# Altium schematic sheet main_scheme.SchDoc(main_scheme) (continued)

## Library data of the parts
C1 C2 = CAP 0402 - 27pF: Cap Ceramic 0402, 27pF, 25V,10%; PartNumber: C0402C270K3GACTU; Component Kind: Standard; ComponentLink1Description: myLinks; ComponentLink1URL: https://eu.mouser.com/ProductDetail/KEMET/C0402C270K3GACTU?qs=v7s4VpRpa0t5WS52qYyghQ%3D%3D; Library Name: Schlib1.SchLib; Package: 0402; Supplier 1: Mouser; Supplier Part Number 1: 80-C0402C270K3G; Value: 27pF
C3 C42 = CAP-0603-4.7uF: General Purpose Ceramic Capacitor, 0603,4.7uF,35V,10%; PartNumber: C1608X5R1V475K080AC; Component Kind: Standard; ComponentLink1Description: myLinks; ComponentLink1URL: https://cz.mouser.com/ProductDetail/TDK/C1608X5R1V475K080AC?qs=dfay7wIA1uF9DVjUL4K4oA%3D%3D; Library Name: Schlib1.SchLib; Package: 0603; Supplier 1: Mouser; Supplier Part Number 1: 810-C1608X5R1V475K; Value: 4.7uF
C4-C15 C18-C20 C40 C41 C43 = CAP 0402 - 100nF: Cap Ceramic 0402,0.1uF, 25V,10%; PartNumber: C0402C104K3RACTU; Component Kind: Standard; ComponentLink1Description: myLinks; ComponentLink1URL: https://eu.mouser.com/ProductDetail/KEMET/C0402C104K3RACTU?qs=YCcZ0GhAU2q7BaxZ%2FluQHA%3D%3D; Library Name: Schlib1.SchLib; Package: 0402; Supplier 1: Mouser; Supplier Part Number 1: 80-C0402C104K3R; Value: 100nF
C16 C17 = CAP-0603-2.2uF: General Purpose Ceramic Capacitor, 0603,2.2uF,35V,10%; PartNumber: C1608JB1V225K080AC; Component Kind: Standard; ComponentLink1Description: myLinks; ComponentLink1URL: https://cz.mouser.com/ProductDetail/TDK/C1608JB1V225K080AC?qs=xLDY6iXSiQbY%2FrhN9AA4AA%3D%3D; Library Name: Schlib1.SchLib; Package: 0603; Supplier 1: Mouser; Supplier Part Number 1: 810-C1608JB1V225K08C; Value: 2.2uF
D4 D10 = DIODE -FW:3A - 380mV, Rev: 40V: Schottky DIODE -  DO-214AC-2 -FW:3A - 380mV, Rev: 40V; PartNumber: SSA34HE3_A/I; Component Kind: Standard; ComponentLink1Description: New link; ComponentLink1URL: https://cz.mouser.com/ProductDetail/Vishay-General-Semiconductor/SSA34HE3_A-I?qs=asPD7ZL2j3VimMFbwwU%2FoA%3D%3D; Library Name: Schlib1.SchLib; Package: DO-214AC-2; Supplier 1: Mouser; Supplier Part Number 1: 78-SSA34HE3_A/I; Value: FW:3A - 380mV, Rev: 40V
D8 D13 = LED - 0603 - RED - 2V2: LED RED DIFFUSED 0603 SMD; PartNumber: SML-D12U1WT86; Value: RED LED - 2.2V; Component Kind: Standard; ComponentLink1Description: myLinks; ComponentLink1URL: https://cz.mouser.com/ProductDetail/ROHM-Semiconductor/SML-D12U1WT86?qs=M3jcYzEJUdEySuGkbjHEtQ%3D%3D; Library Name: Schlib1.SchLib; Package: 0602; Supplier 1: Mouser; Supplier Part Number 1: 755-SML-D12U1WT86
D14 = Diode - SK53AFL-TP: Diode - 5A shottky Rectifier, Fw: 5A, 0.7V Revers: 30V, DO-221AC-2; Component Kind: Standard; ComponentLink1Description: myLinks; ComponentLink1URL: https://cz.mouser.com/ProductDetail/Micro-Commercial-Components-MCC/SK53AFL-TP?qs=sGAEpiMZZMtbRapU8LlZD%252B6h%2FWulpAkrF6E5wLTt2R0xTCwpYWNMog%3D%3D; Library Name: Schlib1.SchLib; Package: DO-221AC-2; Supplier 1: Mouser; Supplier Part Number 1: 833-SK53AFL-TP; Value: Fw: 5A, 0.7V Revers: 30V
J1 = connector - 25x2 - 2x2mm - right angle: Connector - connector - 25x2 - 2x2mm, SAMTEC - right angle; PartNumber: STMM-125-02-S-D-RA; Component Kind: Standard; ComponentLink1Description: myLinks; ComponentLink1URL: https://cz.mouser.com/ProductDetail/Samtec/STMM-125-02-S-D-RA?qs=rU5fayqh%252BE3bRISkmlmqKg%3D%3D; Library Name: Schlib1.SchLib; Package: 25x2 - 2x2mm -right angle; Supplier 1: Mouser; Supplier Part Number 1: 200-STMM12502SDRA; Value: 25x2 - 2x2mm -right angle
J2 J16 = Connector - 2x5 -1.27 x 1.27mm: Connector - 2x5positions -  1.27mm x1.27mm; PartNumber: FTSH-105-04-F-D; Value: 2x5 1.27mm x 1.27mm; Component Kind: Standard; ComponentLink1Description: myLinks; ComponentLink1URL: https://cz.mouser.com/ProductDetail/Samtec/FTSH-105-04-F-D?qs=0lQeLiL1qyZx0H067ICkhw%3D%3D; Library Name: Schlib1.SchLib; Package: 2x5positions -  1.27mm x1.27mm; Supplier 1: Mouser; Supplier Part Number 1: 200-FTSH10504FDV
J4 J5 J10 J12-J14 J17-J22 = WAGO - single - 236-401: Wago connector - PCB to wire - single - 24A 320V; Component Kind: Standard; ComponentLink1Description: myLinks; ComponentLink1URL: https://cz.farnell.com/wago/236-401/terminal-block-wire-to-brd-1pos/dp/1891620?gclid=CjwKCAiAu5agBhBzEiwAdiR5tFj9uwuLgrlShO914YuSUEtsU0o4Hiox7ZjRnpSnFBbtyRYLbKYJWxoC0xgQAvD_BwE&mckv=sEoohdYPd_dc|pcrid|263733373421|kword|+wago%20+236-401|match|b|plid||slid||product||pgrid|56870816529|ptaid|kwd-298399736559|&CMP=KNC-GCZ-GEN-SKU-MDC; Library Name: Schlib1.SchLib; PartNumber: 236-401; Supplier 1: Farnell; Supplier Part Number 1: 1891620; Value: 24A 320V
P1 P2 P8 P9 P11 = Header - 3x1 2.54mm: Headers & Wire Housings 3P HEADER GOLD 15u single row; PartNumber: 5-146280-3; Component Kind: Standard; ComponentLink1Description: myLinks; ComponentLink1URL: https://cz.mouser.com/ProductDetail/TE-Connectivity/5-146280-3?qs=bwpFY26eRVf7xnwkMZzVxA%3D%3D; Library Name: Schlib1.SchLib; Package: 3x1 2.54mm; Supplier 1: Mouser; Supplier Part Number 1: 571-5-146280-3; Value: 3x1 2.54mm
P3 P10 P12 P13 = Header - 2x1 2.54mm: Male Header, Pitch 2.54 mm, 1 x 2 Position, Height 8.21 mm, Tail Length 3.05 mm, -65 to 105 degC, RoHS, Bulk; PartNumber: 5-146280-2; Component Kind: Standard; ComponentLink1Description: myLinks; ComponentLink1URL: https://cz.mouser.com/ProductDetail/TE-Connectivity/5-146280-2?qs=bwpFY26eRVcxHP%252BQexhLNQ%3D%3D; Library Name: Schlib1.SchLib; Package: 2x1 2.54mm; Supplier 1: Mouser; Supplier Part Number 1: 571-5-146280-2; Value: 2x1 2.54mm
R1-R4 R33 R51 = Resistor - 0402 -10k: Resistor - 0402 -  10k - 5% - 125mW; PartNumber: RC0402JR-7W10KL; Component Kind: Standard; ComponentLink1Description: myLinks; ComponentLink1URL: https://cz.mouser.com/ProductDetail/YAGEO/RC0402JR-7W10KL?qs=tggtontpCXPnY%2FhgQXv%2FLg%3D%3D; Library Name: Schlib1.SchLib; Package: 0402; Supplier 1: Mouser; Supplier Part Number 1: 603-RC0402JR-7W10KL; Value: 10k
R10 = Resistor - 0402 -120R: Resistor - 0402 -  120R - 1% - 100mW; PartNumber: ERJ-S02F1200X; Component Kind: Standard; ComponentLink1Description: myLinks; ComponentLink1URL: https://cz.mouser.com/ProductDetail/Panasonic/ERJ-S02F1200X?qs=Zyl8A9hlmJqrTWz%252BBOpJnw%3D%3D; Library Name: Schlib1.SchLib; Package: 0402; Supplier 1: Mouser; Supplier Part Number 1: 667-ERJ-S02F1200X; Value: 120R
R36 R42 = Resistor - 0402 - 4.87k: Resistor - 0402 -  4.87k - 1% - 100mW; PartNumber: ERJ-2RKF4871X; Component Kind: Standard; ComponentLink1Description: myLinks; ComponentLink1URL: https://cz.mouser.com/ProductDetail/Panasonic/ERJ-2RKF4871X?qs=YMBIwoNQYdV9ZzOLxQ6NUw%3D%3D; Library Name: Schlib1.SchLib; Package: 0402; Supplier 1: Mouser; Supplier Part Number 1: 667-ERJ-2RKF4871X; Value: 4.87k
R41 = RESISTOR - 0603 - 1k: Resistor 0603 1KR 100mW 1%; PartNumber: RT0603FRE071KL; Component Kind: Standard; ComponentLink1Description: myLinks; ComponentLink1URL: https://cz.mouser.com/ProductDetail/YAGEO/RT0603FRE071KL?qs=8cPjvKtxWv68ZVEzoi6JxQ%3D%3D; Library Name: Schlib1.SchLib; Package: 0603; Supplier 1: Mouser; Supplier Part Number 1: 603-RT0603FRE071KL; Value: 1k
R47-R50 = RESISTOR - 0603 - 0R: Resistor 0603 0R; PartNumber: ERJ-3GEY0R00V; Component Kind: Standard; ComponentLink1Description: myLinks; ComponentLink1URL: https://cz.mouser.com/ProductDetail/Panasonic/ERJ-3GEY0R00V?qs=66DK8nO8gJALIOqOWgiVwg%3D%3D; Library Name: Schlib1.SchLib; Package: 0603; Supplier 1: Mouser; Supplier Part Number 1: 667-ERJ-3GEY0R00V; Value: 0R
S1 = Button - smd -2 poles: Push button - 2poles,  L:2.5mm, W:3mm, H:1.2mm, 12VDC - 50mA; Value: 2 poles button - 12V 50mA; Component Kind: Standard; ComponentLink1Description: myLinks; ComponentLink1URL: https://www.mouser.co.uk/ProductDetail/Omron-Electronics/B3U-1000P?qs=AO7BQMcsEu4ip80xyf2FwA%3D%3D; Library Name: Schlib1.SchLib; Package: L:2.5mm, W:3mm, H:1.2mm; PartNumber: B3U-1000P; Supplier 1: Mouser; Supplier Part Number 1: 653-B3U-1000P
U1 = STM32F407ZGT6: ARM Cortex-M4 32-bit MCU+FPU, 210 DMIPS, 1024 kB Flash, 192 kB Internal RAM, 114 I/Os, 144-pin LQFP, -40 to 85 degC, Tray; Value: Cortex - M4 uC; Component Kind: Standard; ComponentLink1Description: myLinks; ComponentLink1URL: https://cz.mouser.com/ProductDetail/STMicroelectronics/STM32F407ZGT6?qs=Z8%252BeY1k3TIKo142SZNWnSQ%3D%3D; Library Name: Schlib1.SchLib; Package: LQFP144; PartNumber: STM32F407ZGT6; Supplier 1: Mouser; Supplier Part Number 1: 511-STM32F407ZGT6
U6 = MAC_EEPROM_I2C: 2-Kbit (256 x 8), 2-wire Serial EEPROM with a Factory-Programmed EUI-64(TM)Address Plus an Embedded Unique 128-bit Serial Number, 1.7 to 5.5 V, -40 to 85 degC, 5-Pin SOT23 (5TS1), Tape and Reel; Value: EEPROM, 2-Kbit, I2C, with MAC; Component Kind: Standard; ComponentLink1Description: myLinks; ComponentLink1URL: https://cz.mouser.com/ProductDetail/Microchip-Technology-Atmel/AT24MAC602-STUM-T?qs=s44pgLhgXQiuNdSpdYyLLA%3D%3D; Library Name: Schlib1.SchLib; Package: SOT-23-5; Supplier 1: Mouser; Supplier Part Number 1: 556-AT24MAC602-STUMT
U7 = MUX -  SOT-23 - TMUX1308QDYYRQ1: Multiplexer Switch ICs Automotive 5-V, 8:1, 1-channel multiplexer with injection current control and 1.8V logic 16-SOT-23-THN -40 to 125; Value: 8:1 MUX; Component Kind: Standard; ComponentLink1Description: myLinks; ComponentLink1URL: https://cz.mouser.com/ProductDetail/Texas-Instruments/TMUX1308QDYYRQ1?qs=xZ%2FP%252Ba9zWqaqz9D2kpWQ%2FA%3D%3D; Library Name: Schlib1.SchLib; Package: SOT-23-THIN-16; Supplier 1: Mouser; Supplier Part Number 1: 595-TMUX1308QDYYRQ1
U8 = CAN - PHY - SN65HVD232D: 3.3 V CAN Transceiver, 17 mA, -40 to 85 degC, 8-pin SOIC (D), Green (RoHS & no Sb/Br); Value: 1Mbit CAN , 3-3.6VDC; Component Kind: Standard; ComponentLink1Description: myLinks; ComponentLink1URL: https://eu.mouser.com/ProductDetail/Texas-Instruments/SN65HVD232D?qs=QViXGNcIEAueLIS9b8IyYg%3D%3D; Library Name: Schlib1.SchLib; Package: SOIC-8; PartNumber: SN65HVD232D; Supplier 1: Mouser; Supplier Part Number 1: 595-SN65HVD232D
U12 = OPAMP - 2CH AD8532ARMZ-REEL: Double Channel Rail-to-Rail Operational Amplifier, 3 MHz BW, 5 V/us SR, Industrial, 8-pin SOP (RM-8), Reel; Value: RAIL TO RAIL OPAMP 3-6V; Component Kind: Standard; ComponentLink1Description: myLinks; ComponentLink1URL: https://cz.mouser.com/ProductDetail/Analog-Devices/AD8532ARMZ-REEL?qs=%2FtpEQrCGXCwVVXkbCBPc6A%3D%3D; Library Name: Schlib1.SchLib; Package: MSOP-8; PartNumber: AD8532ARMZ-REEL; Supplier 1: Mouser; Supplier Part Number 1: 584-AD8532ARMZ-R
VR1 VR3 = TRIMMER - 1k -100mW: Variable Resistor Trimmer - 1k - 25% -100mW - single turn; PartNumber: TC33X-2-102E; Component Kind: Standard; ComponentLink1Description: myLinks; ComponentLink1URL: https://cz.mouser.com/ProductDetail/Bourns/TC33X-2-102E?qs=2hAPiALdQ0nRULMRC6GCtg%3D%3D; Library Name: Schlib1.SchLib; Package: 3mm SMD TRIMPOT; Supplier 1: Mouser; Supplier Part Number 1: 652-TC33X-2-102E
VR4 VR5 = TRIMMER - 50k -100mW: Variable Resistor Trimmer - 50k - 25% -100mW - single turn; PartNumber: TC33X-2-102E; Component Kind: Standard; ComponentLink1Description: myLinks; ComponentLink1URL: https://cz.mouser.com/ProductDetail/Bourns/TC33X-2-503E?qs=tEaODSGlU8NgxHjveDtEEg%3D%3D; Library Name: Schlib1.SchLib; Package: 3mm SMD TRIMPOT; Supplier 1: Mouser; Supplier Part Number 1: 652-TC33X-2-102E
Y1 = 8MHz-Osc: Crystal 8MHz ±10ppm CL=18pF SMD-4 5mm x 3.2mm; PartNumber: ABM3B-8.000MHZ-D2-T; Component Kind: Standard; ComponentLink1Description: myLinks; ComponentLink1URL: https://cz.mouser.com/ProductDetail/ABRACON/ABM3B-8.000MHZ-D2-T?qs=icabnvfcbsHVTU9nAlXQRw%3D%3D; Library Name: Schlib1.SchLib; Package: SMD 4pin OSC; Supplier 1: Mouser; Supplier Part Number 1: 815-ABM3B8000MHZD2T; Value: 8MHz
